# Altium schematic sheet 04 - Camera Interface.SchDoc
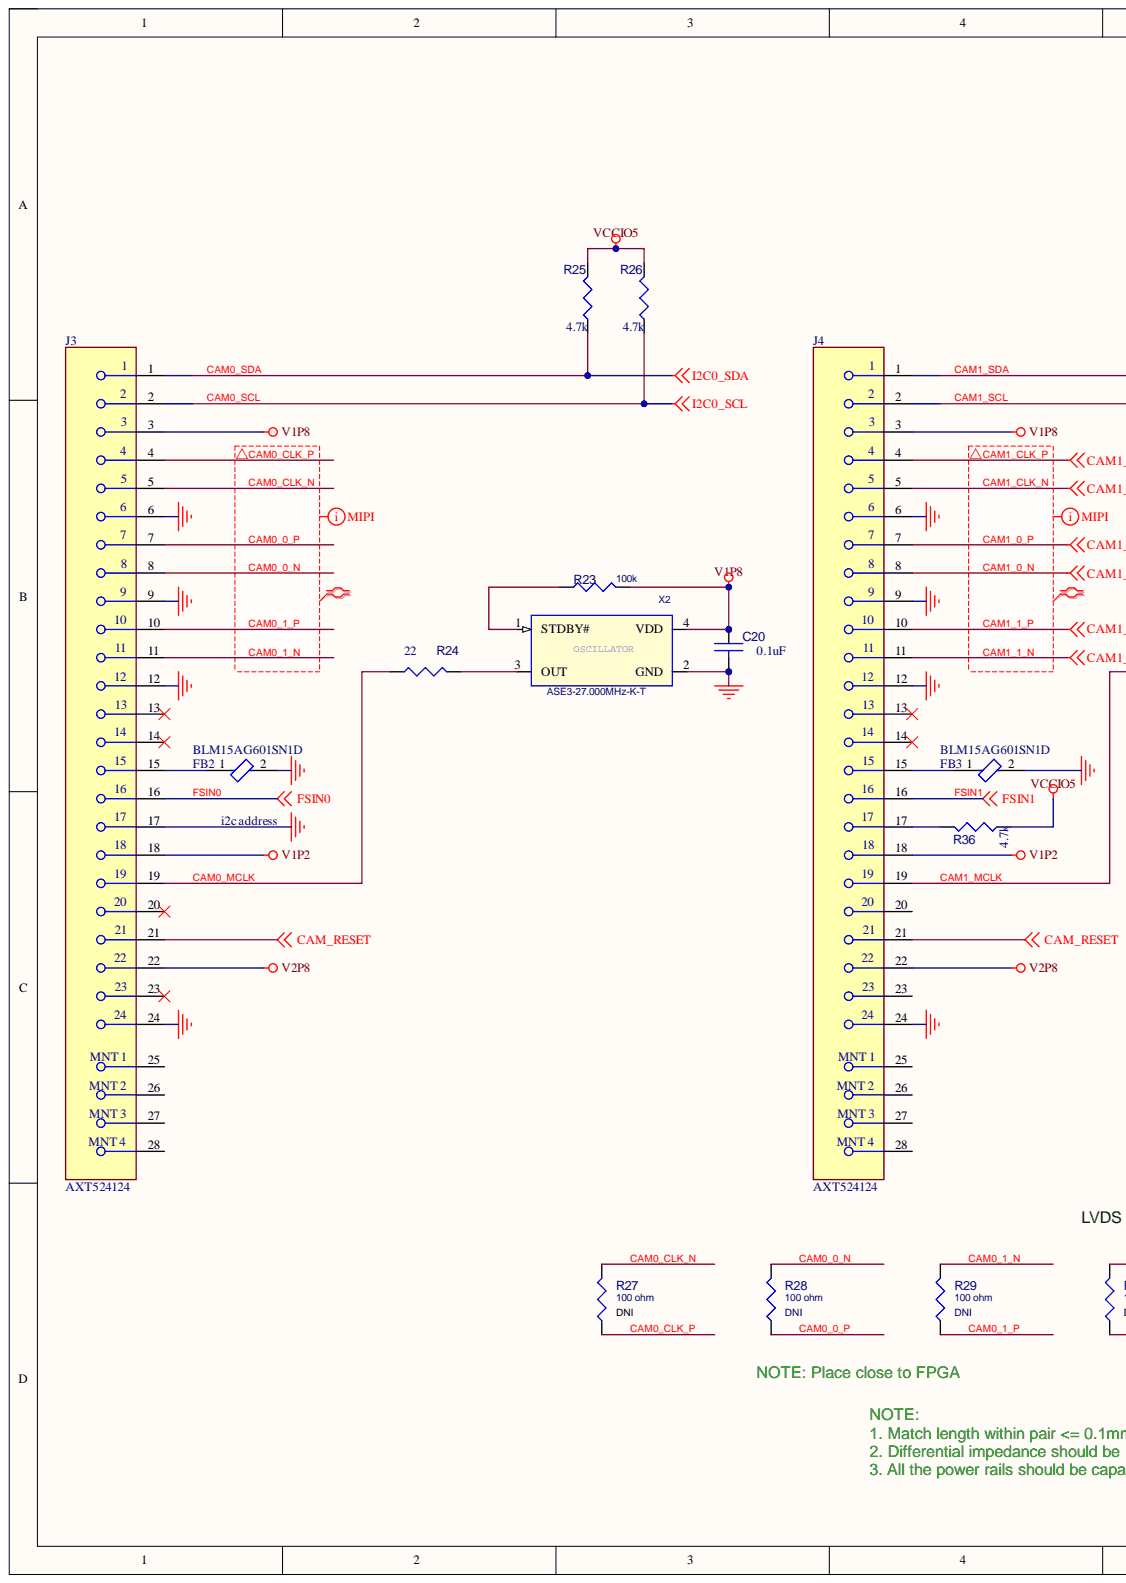
[diagram p0: 04 - Camera Interface.SchDoc, left]
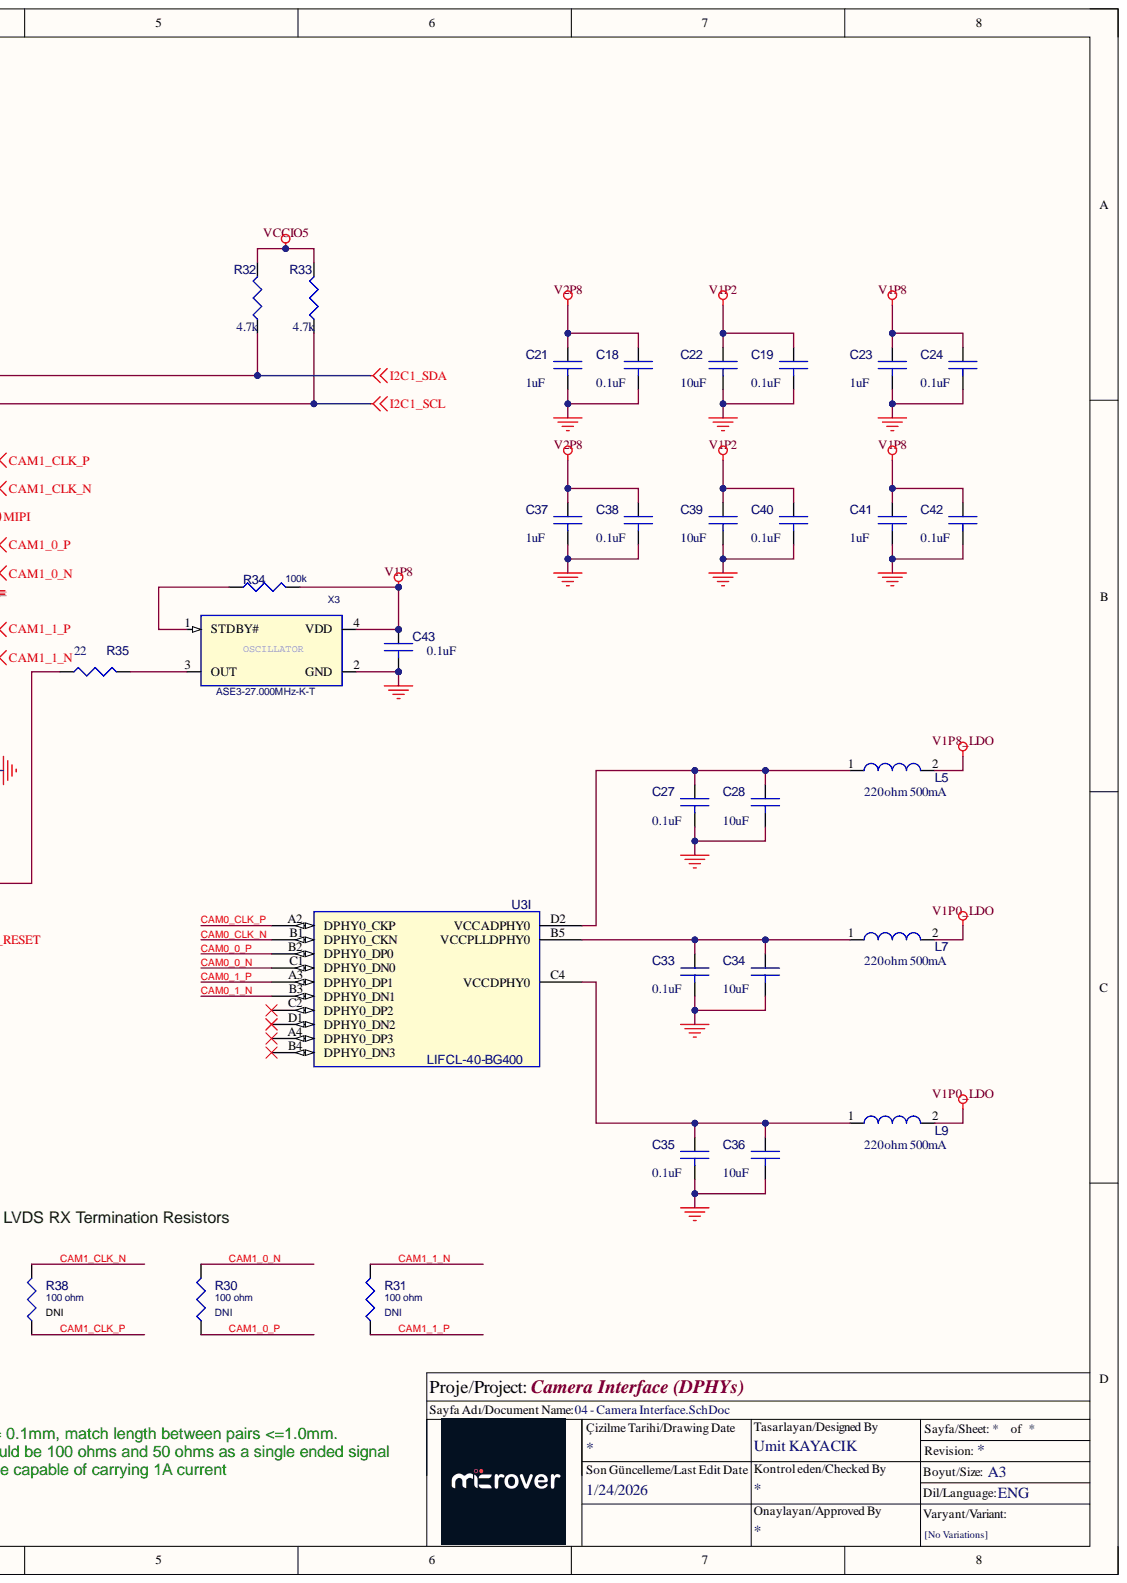
[diagram p1: 04 - Camera Interface.SchDoc, right]

## Parts
C18  0.1uF  Part Reference: C18  [C0201]
C19  0.1uF  Part Reference: C19  [C0201]
C20  0.1uF  Part Reference: C20  [C0201]
C21  1uF  Part Reference: C21  [C0603]
C22  10uF  Part Reference: C22  [C0603]
C23  1uF  Part Reference: C23  [C0603]
C24  0.1uF  Part Reference: C24  [C0201]
C27  0.1uF  Part Reference: C27  [C0201]
C28  10uF  Part Reference: C28  [C0402]
C33  0.1uF  Part Reference: C33  [C0201]
C34  10uF  Part Reference: C34  [C0402]
C35  0.1uF  Part Reference: C35  [C0201]
C36  10uF  Part Reference: C36  [C0402]
C37  1uF  [C0603]
C38  0.1uF  [C0201]
C39  10uF  [C0603]
C40  0.1uF  [C0201]
C41  1uF  [C0603]
C42  0.1uF  [C0201]
C43  0.1uF  [C0201]
FB2  BLM15AG601SN1D  [FP-BLM15-0_05-MFG]
FB3  BLM15AG601SN1D  [FP-BLM15-0_05-MFG]
J3  AXT524124  [FP-AXT524124-MFG]
J4  AXT524124  [FP-AXT524124-MFG]
L5  220ohm 500mA  Part Reference: L5  [FB0402]
L7  220ohm 500mA  Part Reference: L7  [FB0402]
L9  220ohm 500mA  Part Reference: L9  [FB0402]
R23  100k  Part Reference: R23  [R0402]
R24  22  Part Reference: R24  [R0402]
R25  4.7k  Part Reference: R25  [R0402]
R26  4.7k  Part Reference: R26  [R0402]
R27  100 ohm  Assy: DNI  Part Reference: R27  [R0201]
R28  100 ohm  Assy: DNI  Part Reference: R28  [R0201]
R29  100 ohm  Assy: DNI  Part Reference: R29  [R0201]
R30  100 ohm  Assy: DNI  Part Reference: R30  [R0201]
R31  100 ohm  Assy: DNI  Part Reference: R31  [R0201]
R32  4.7k  [R0402]
R33  4.7k  [R0402]
R34  100k  [R0402]
R35  22  [R0402]
R36  4.7k  [R0402]
R38  100 ohm  Assy: DNI  [R0201]
U3  LIFCL-40-BG400  [LIFCL-40-BG400]  (part I)
X2  ASE3-27.000MHz-K-T  Part Reference: X2  [27MHZ]
X3  ASE3-27.000MHz-K-T  [27MHZ]

## Nets
CAM_RESET: J3.21 J4.21
GND: C18.2 C19.2 C20.2 C21.2 C22.2 C23.2 C24.2 C27.2 C28.2 C33.2 C34.2 C35.2 C36.2 C37.2 C38.2 C39.2 C40.2 C41.2 C42.2 C43.2 FB2.2 FB3.2 J3.6 J3.9 J3.12 J3.17 J3.24 J4.6 J4.9 J4.12 J4.24 X2.2(GND) X3.2(GND)
V1P0_LDO: L7.2 L9.2
V1P2: C19.1 C22.1 C39.1 C40.1 J3.18 J4.18
V1P8: C20.1 C23.1 C24.1 C41.1 C42.1 C43.1 J3.3 J4.3 R23.2 R34.2 X2.4(VDD) X3.4(VDD)
V1P8_LDO: L5.2
V2P8: C18.1 C21.1 C37.1 C38.1 J3.22 J4.22
VCCIO5: R25.1 R26.1 R32.1 R33.1 R36.2
CAM0_0_N: J3.8 R28.2 U3.C1(DPHY0_DN0)
CAM0_0_P: J3.7 R28.1 U3.B2(DPHY0_DP0)
CAM0_1_N: J3.11 R29.2 U3.B3(DPHY0_DN1)
CAM0_1_P: J3.10 R29.1 U3.A3(DPHY0_DP1)
CAM0_CLK_N: J3.5 R27.2 U3.B1(DPHY0_CKN)
CAM0_CLK_P: J3.4 R27.1 U3.A2(DPHY0_CKP)
CAM0_MCLK: J3.19 R24.2
CAM0_SCL: J3.2 R26.2
CAM0_SDA: J3.1 R25.2
CAM1_0_N: J4.8 R30.2
CAM1_0_P: J4.7 R30.1
CAM1_1_N: J4.11 R31.2
CAM1_1_P: J4.10 R31.1
CAM1_CLK_N: J4.5 R38.2
CAM1_CLK_P: J4.4 R38.1
CAM1_MCLK: J4.19 R35.2
CAM1_SCL: J4.2 R33.2
CAM1_SDA: J4.1 R32.2
FSIN0: J3.16
FSIN1: J4.16
NetC27_1: C27.1 C28.1 L5.1 U3.D2(VCCADPHY0)
NetC33_1: C33.1 C34.1 L7.1 U3.B5(VCCPLLDPHY0)
NetC35_1: C35.1 C36.1 L9.1 U3.C4(VCCDPHY0)
NetFB2_1: FB2.1 J3.15
NetFB3_1: FB3.1 J4.15
NetJ4_17: J4.17 R36.1
NetR23_1: R23.1 X2.1(STDBY#)
NetR24_1: R24.1 X2.3(OUT)
NetR34_1: R34.1 X3.1(STDBY#)
NetR35_1: R35.1 X3.3(OUT)

Not connected: J3 13 14 20 23 25 26 27 28; J4 13 14 20 23 25 26 27 28; U3 A4(DPHY0_DP3) B4(DPHY0_DN3) C2(DPHY0_DP2) D1(DPHY0_DN2)

## Library data of the parts
C18-C20 C24 C27 C33 C35 = 0u1_0402: CAP CER 0.1UF 25V 10% X5R 0201; Manufacturer: Murata; Manufacturer Part Number: GRM033R61E104KE14J; Class: Discrete; Assy: -; Component Kind: Standard; Digikey P/N: 399-3521-2-ND; PCB Footprint: C0201; Value: 0.1uF
C21-C23 = 10uF_0603: CAP CECAP CER 10UF 10V X5R 20% 0603; Manufacturer: Taiyo Yuden; Manufacturer Part Number: LMK107BJ106MALTD; Class: Discrete; Assy: -; Component Kind: Standard; Digikey P/N: 587-2562-1-ND; PCB Footprint: C0603
  C21 C23: Value: 1uF
  C22: Value: 10uF
C28 C34 C36 = 10uF_0603: CAP CER 10UF 10V X5R 0402; Manufacturer: Samsung; Manufacturer Part Number: CL05A106MP8NUB8; Class: Discrete; Assy: -; Component Kind: Standard; Digikey P/N: 587-2562-1-ND; PCB Footprint: C0402; Value: 10uF
C37 = 10uF_0603: CAP CECAP CER 10UF 10V X5R 20% 0603; Manufacturer: Taiyo Yuden; Manufacturer Part Number: LMK107BJ106MALTD; Part Reference: C21; Class: Discrete; Assy: -; Component Kind: Standard; Digikey P/N: 587-2562-1-ND; PCB Footprint: C0603; Value: 1uF
C38 = 0u1_0402: CAP CER 0.1UF 25V 10% X5R 0201; Manufacturer: Murata; Manufacturer Part Number: GRM033R61E104KE14J; Part Reference: C18; Class: Discrete; Assy: -; Component Kind: Standard; Digikey P/N: 399-3521-2-ND; PCB Footprint: C0201; Value: 0.1uF
C39 = 10uF_0603: CAP CECAP CER 10UF 10V X5R 20% 0603; Manufacturer: Taiyo Yuden; Manufacturer Part Number: LMK107BJ106MALTD; Part Reference: C22; Class: Discrete; Assy: -; Component Kind: Standard; Digikey P/N: 587-2562-1-ND; PCB Footprint: C0603; Value: 10uF
C40 = 0u1_0402: CAP CER 0.1UF 25V 10% X5R 0201; Manufacturer: Murata; Manufacturer Part Number: GRM033R61E104KE14J; Part Reference: C19; Class: Discrete; Assy: -; Component Kind: Standard; Digikey P/N: 399-3521-2-ND; PCB Footprint: C0201; Value: 0.1uF
C41 = 10uF_0603: CAP CECAP CER 10UF 10V X5R 20% 0603; Manufacturer: Taiyo Yuden; Manufacturer Part Number: LMK107BJ106MALTD; Part Reference: C23; Class: Discrete; Assy: -; Component Kind: Standard; Digikey P/N: 587-2562-1-ND; PCB Footprint: C0603; Value: 1uF
C42 = 0u1_0402: CAP CER 0.1UF 25V 10% X5R 0201; Manufacturer: Murata; Manufacturer Part Number: GRM033R61E104KE14J; Part Reference: C24; Class: Discrete; Assy: -; Component Kind: Standard; Digikey P/N: 399-3521-2-ND; PCB Footprint: C0201; Value: 0.1uF
C43 = 0u1_0402: CAP CER 0.1UF 25V 10% X5R 0201; Manufacturer: Murata; Manufacturer Part Number: GRM033R61E104KE14J; Part Reference: C20; Class: Discrete; Assy: -; Component Kind: Standard; Digikey P/N: 399-3521-2-ND; PCB Footprint: C0201; Value: 0.1uF
FB2 FB3 = CMP-0686-00187-4: Chip Ferrite Bead, 0402, 600Ω @ 100MHz, 0.52Ω, 25%, 300mA; DC Resistance (DCR): 520mR; DC Resistance-Max: 600mR; Impedance: 600R; Material: Steel; Number of Circuits: 1; Shielding: Unshielded; Case Code (Imperial): 0402; Case Code (Metric): 1005; Case/Package: 0402; Component Kind: Standard; Core Material: Ferrite; Current Rating: 300mA; DC Current: 300mA; Depth: 0.55mm; Frequency: 100MHz; Height: 0.5mm; Lead Free: Lead Free; Length: 1mm; Library Name: Altium Content Vault; Max Current Rating: 300mA; Max DC Current: 300mA; Max Operating Temperature: 125°C; Military Standard: Not; Min Operating Temperature: -55°C; Number of Lines: 1; Number of Pins: 2; Package Quantity: 10000; Packaging: Tape and Reel; Radiation Hardening: No; REACH SVHC: No SVHC; RoHS Compliant: Yes; Series: BLM15AG_SN; Termination: SMD/SMT; Test Frequency: 100MHz; Tolerance: 25%; Width: 0.5mm
J3 J4 = CMP-00287-07879795-1: AXT524124 24 Position 0.4 mm Pitch Dual Row Board to Board Socket Narrow Pitch Connector; Contact Resistance: 90mΩ; Current Rating: 300mA; Gender: Receptacle; Insulation Resistance: 1GΩ; Mated Stacking Heights: 1mm; Max Current Rating: 5A; Max Voltage Rating (AC): 60V; Max Voltage Rating (DC): 6.06kV; Number of Contacts: 24; Pitch: 400µm; Ambient Temperature Range High: 85°C; Component Kind: Standard; Connector Type: Socket; Contact Material: Gold, Nickel; Contact Plating: Gold; Depth: 3mm; Height: 1.17mm; Housing Material: Polymer; Lead Free: Lead Free; Length: 7.3mm; Library Name: Altium Content Vault; Max Operating Temperature: 85°C; Min Operating Temperature: -55°C; Mount: Surface Mount; NexarPartId: 7879795; Number of Positions: 24; Number of Rows: 2; Orientation: Straight; Packaging: Cut Tape (CT); Radiation Hardening: No; RoHS: Compliant; Termination: Solder; Voltage Rating: 60V
L5 L7 L9 = FB0603: FERRITE BEAD 600 OHM 0402 1LN; Manufacturer: Murata; Manufacturer Part Number: BLM15AX601SN1D; Class: Discrete; Assy: -; Component Kind: Standard; Digikey P/N: 490-1014-1-ND; PCB Footprint: FB0402; Value: 220ohm 500mA
R23 = RES_100K_1W16_0402_5P: RES 100K OHM 1/10W 1% 0402 SMD; Manufacturer: Panasonic; Manufacturer Part Number: ERJ-2RKF1003X; DIST: FLAT; MAX_TEMP: RTMAX; TC1: 0; Total Area(sq-mm): 0.5; Unit Price (USD): 0.02; Wattage: 1/16W; Assy: -; Component Kind: Standard; Length(mm): 1; MFG: Panasonic; MFG P/N: ERJ-2RKF1003X; PART_NUMBER: ERJ-2RKF1003X; PCB Footprint: R0402; TC2: 0; Value: 100k; Width(mm): 0.5
R24 = 0R_0603: RES SMD 22 OHM 1% 1/10W 0402; Manufacturer: Panasonic; Manufacturer Part Number: ERJ-2RKF22R0X; Class: Discrete; Assy: -; Component Kind: Standard; Digikey P/N: 311-0.0GRTR-ND; MFG: Panasonic; MFG P/N: ERJ-2RKF22R0X; PCB Footprint: R0402; Value: 22
R25 R26 = 5k1_0402: RES SMD 2.2K OHM 1% 1/10W 0402; Manufacturer: Panasonic; Manufacturer Part Number: ERJ-2RKF2201X; Class: Discrete; Assy: -; BOM NOTE: -; Component Kind: Standard; Digikey P/N: 311-5.10KLRTR-ND; PCB Footprint: R0402; Value: 4.7k
R27-R31 = RES_100K_1W16_0402_5P: RES SMD 100 OHM 1% 1/20W 0201; Manufacturer: Yageo; Manufacturer Part Number: RC0201FR-07100RL; DIST: FLAT; MAX_TEMP: RTMAX; TC1: 0; Total Area(sq-mm): 0.5; Unit Price (USD): 0.02; Wattage: 1/16W; Component Kind: Standard; Length(mm): 1; MFG: Yageo; MFG P/N: RC0201FR-07100RL; PCB Footprint: R0201; TC2: 0; Value: 100 ohm; Width(mm): 0.5
R32 = 5k1_0402: RES SMD 2.2K OHM 1% 1/10W 0402; Manufacturer: Panasonic; Manufacturer Part Number: ERJ-2RKF2201X; Part Reference: R25; Class: Discrete; Assy: -; BOM NOTE: -; Component Kind: Standard; Digikey P/N: 311-5.10KLRTR-ND; PCB Footprint: R0402; Value: 4.7k
R33 R36 = 5k1_0402: RES SMD 2.2K OHM 1% 1/10W 0402; Manufacturer: Panasonic; Manufacturer Part Number: ERJ-2RKF2201X; Part Reference: R26; Class: Discrete; Assy: -; BOM NOTE: -; Component Kind: Standard; Digikey P/N: 311-5.10KLRTR-ND; PCB Footprint: R0402; Value: 4.7k
R34 = RES_100K_1W16_0402_5P: RES 100K OHM 1/10W 1% 0402 SMD; Manufacturer: Panasonic; Manufacturer Part Number: ERJ-2RKF1003X; Part Reference: R23; DIST: FLAT; MAX_TEMP: RTMAX; TC1: 0; Total Area(sq-mm): 0.5; Unit Price (USD): 0.02; Wattage: 1/16W; Assy: -; Component Kind: Standard; Length(mm): 1; MFG: Panasonic; MFG P/N: ERJ-2RKF1003X; PART_NUMBER: ERJ-2RKF1003X; PCB Footprint: R0402; TC2: 0; Value: 100k; Width(mm): 0.5
R35 = 0R_0603: RES SMD 22 OHM 1% 1/10W 0402; Manufacturer: Panasonic; Manufacturer Part Number: ERJ-2RKF22R0X; Part Reference: R24; Class: Discrete; Assy: -; Component Kind: Standard; Digikey P/N: 311-0.0GRTR-ND; MFG: Panasonic; MFG P/N: ERJ-2RKF22R0X; PCB Footprint: R0402; Value: 22
R38 = RES_100K_1W16_0402_5P: RES SMD 100 OHM 1% 1/20W 0201; Manufacturer: Yageo; Manufacturer Part Number: RC0201FR-07100RL; Part Reference: R27; DIST: FLAT; MAX_TEMP: RTMAX; TC1: 0; Total Area(sq-mm): 0.5; Unit Price (USD): 0.02; Wattage: 1/16W; Component Kind: Standard; Length(mm): 1; MFG: Yageo; MFG P/N: RC0201FR-07100RL; PCB Footprint: R0201; TC2: 0; Value: 100 ohm; Width(mm): 0.5
U3 = LIFCL-40-BG400: Part Reference: U3; Graphic: LIFCL-40-BG400A.Normal; Implementation Type: <none>; Location X-Coordinate: 0; Name: I17266603; Power Pins Visible: False; Primitive: DEFAULT; Source Library: C:\USERS\SLIU1\DOCUMENTS\PCB_DESIGN\JEDI_EVAL_BOARD\SCHEMATIC\LIFCL40.OLB; SPLIT_INST: TRUE; SWAP_INFO: (S1+S2+S3+S4+S5+S6+S7+S8+S9+S10+S11+S12+S13+S14+S15); Assy: -; Color: Default; Component Kind: Standard; Location Y-Coordinate: 0; PCB Footprint: LIFCL-40-BG400; Source Package: LIFCL-40-BG400; Source Part: LIFCL-40-BG400A.Normal; Value: LIFCL-40-BG400
X2 = OSC_25MHz_10PPM: Standard Clock Oscillators 27.000M 1.8V 30ppm; Manufacturer: ABRACON; MFG: Abracon Corporation; MFG P/N: ASFLMB-25.000MHZ-LY-T; Total Area(sq-mm): 16.83; Unit Price (USD): 1.96; Assy: -; Component Kind: Standard; Length(mm: 5.1; Manufacturer Part Number: ASE3-27.000MHz-K-T; PCB Footprint: 27MHZ; Value: ASE3-27.000MHz-K-T; Width(mm): 3.3
X3 = OSC_25MHz_10PPM: Standard Clock Oscillators 27.000M 1.8V 30ppm; Manufacturer: ABRACON; Part Reference: X2; MFG: Abracon Corporation; MFG P/N: ASFLMB-25.000MHZ-LY-T; Total Area(sq-mm): 16.83; Unit Price (USD): 1.96; Assy: -; Component Kind: Standard; Length(mm: 5.1; Manufacturer Part Number: ASE3-27.000MHz-K-T; PCB Footprint: 27MHZ; Value: ASE3-27.000MHz-K-T; Width(mm): 3.3
